# Altium schematic sheet Resonator filter design.SchDoc("Resonator filter design")
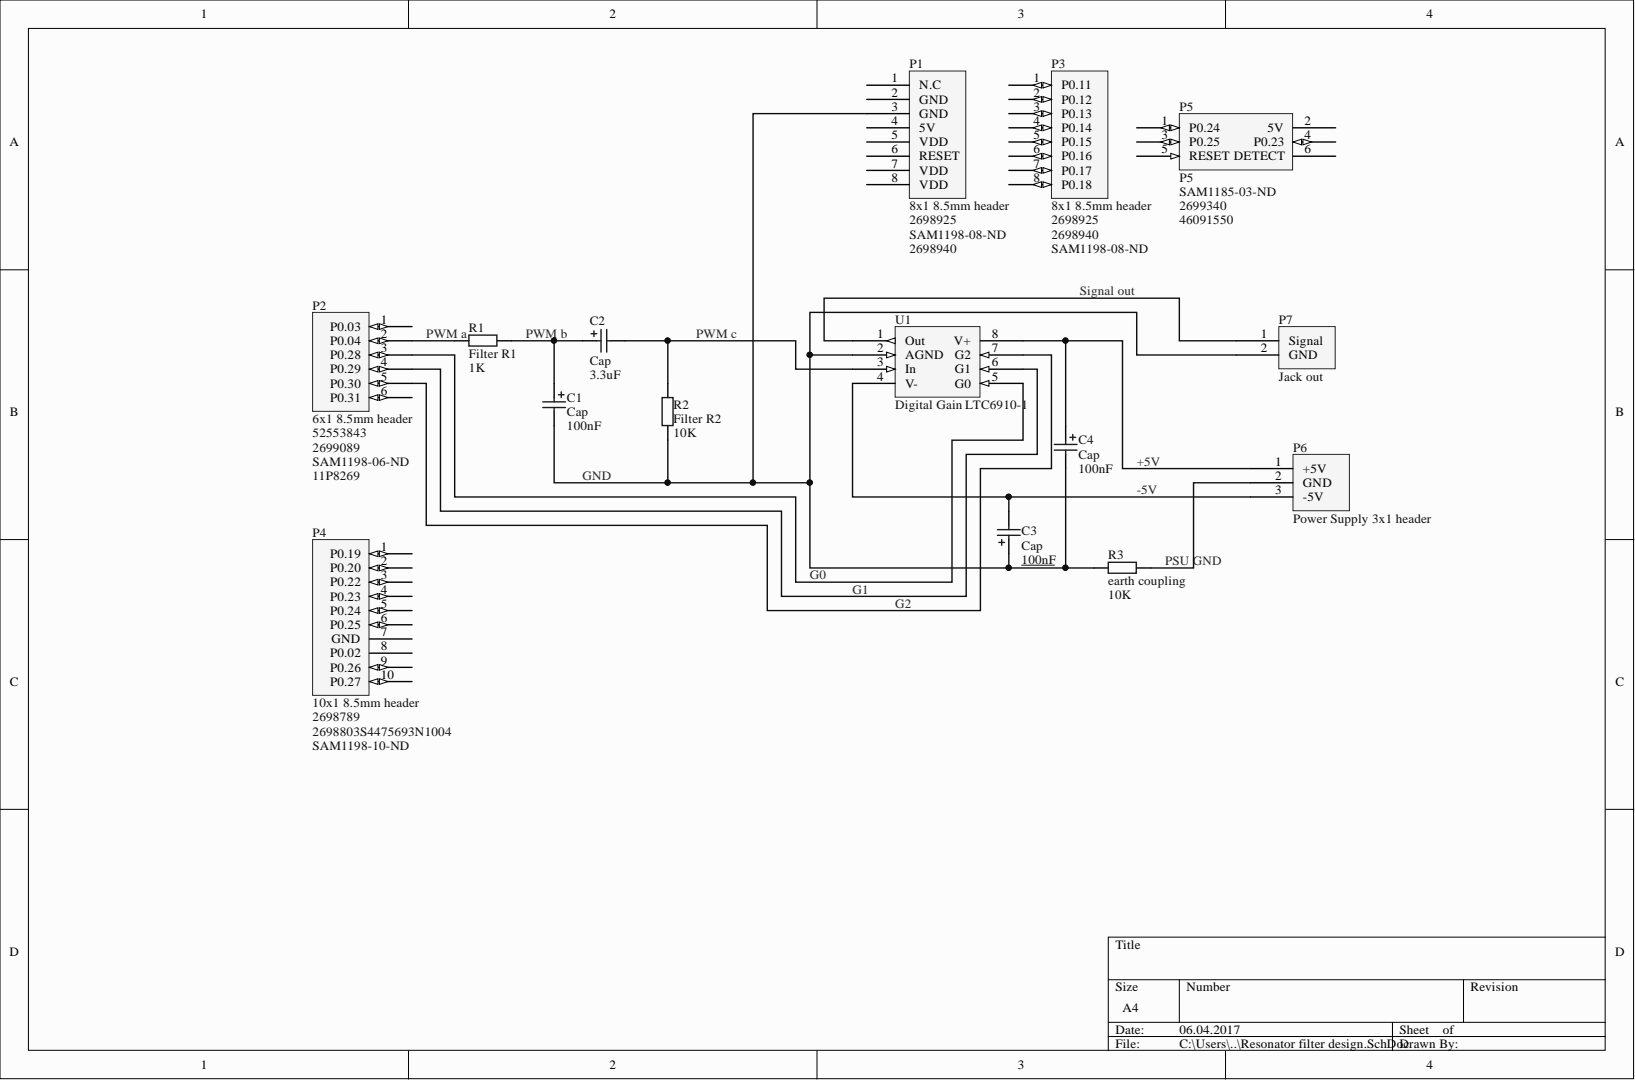
[diagram p0: Resonator filter design.SchDoc]

## Parts
C1  Cap  value 100nF  [Cap 100nF]
C2  Cap  value 3.3uF  [Cap 3.3uF]
C3  Cap  value 100nF  [Cap 100nF]
C4  Cap  value 100nF  [Cap 100nF]
P1  8x1 8.5mm header  Supplier Part Number 1: 2698925  [Header 8x1 8.5mm]
P2  6x1 8.5mm header  Supplier Part Number 1: 52553843  [Header 6x1 8.5mm]
P3  8x1 8.5mm header  Supplier Part Number 1: 2698925  [Header 8x1 8.5mm]
P4  10x1 8.5mm header  Supplier Part Number 1: 2698789  [Header 10x1 8.5mm]
P5  P5  Supplier Part Number 1: SAM1185-03-ND  [ICSP]
P6  Power Supply 3x1 header  [Power Supply connector]
P7  Jack out  [Jack out]
R1  Filter R1  value 1K  [AXIAL-0.4]
R2  Filter R2  value 10K  [AXIAL-0.4]
R3  earth coupling  value 10K  [AXIAL-0.4]
U1  Digital Gain LTC6910-1  [TSOT-23-8]

## Nets
+5V: C4.1 P6.1 U1.8(V+)
-5V: C3.2 P6.3 U1.4(V-)
G0: P2.3(P0.28) U1.5(G0)
G1: P2.4(P0.29) U1.6(G1)
G2: P2.5(P0.30) U1.7(G2)
GND: C1.2 C3.1 C4.2 P1.3(GND) P7.2 R2.1 R3.2 U1.2(AGND)
PSU GND: P6.2 R3.1
PWM a: P2.2(P0.04) R1.1
PWM b: C1.1 C2.1 R1.2
PWM c: C2.2 R2.2 U1.3(In)
Signal out: P7.1 U1.1(Out)

Not connected: P1 1(N.C) 2(GND) 4(5V) 5(VDD) 6(RESET) 7(VDD) 8(VDD); P2 1(P0.03) 6(P0.31); P3 1(P0.11) 2(P0.12) 3(P0.13) 4(P0.14) 5(P0.15) 6(P0.16) 7(P0.17) 8(P0.18); P4 1(P0.19) 2(P0.20) 3(P0.22) 4(P0.23) 5(P0.24) 6(P0.25) 7(GND) 8(P0.02) 9(P0.26) 10(P0.27); P5 1(P0.24) 2(5V) 3(P0.25) 4(P0.23) 5(RESET) 6(DETECT)

## Library data of the parts
C1-C4 = Cap Pol2: Polarized Capacitor (Axial); Simulation: CAP; Signal Integrity: Cap Pol; Published: 8-Jun-2000; PackageReference: POLAR0.8; PackageDescription: Polarized Capacitor; 2 Leads; Library Name: Miscellaneous Devices.IntLib; LatestRevisionNote: Re-released for DXP Platform.; LatestRevisionDate: 17-Jul-2002; Component Kind: Standard; Publisher: Altium Limited
P1 = POWER P1: Supplier Part Number 3: 2698940; Supplier Part Number 2: SAM1198-08-ND; Supplier 3: Arrow; Supplier 2: Digi-Key; Supplier 1: Arrow; Component Kind: Standard; Library Name: Resonator filter design.SCHLIB
P2 = P2: Supplier Part Number 4: 11P8269; Supplier Part Number 3: SAM1198-06-ND; Supplier Part Number 2: 2699089; Supplier 4: Newark; Supplier 3: Digi-Key; Supplier 2: Arrow; Supplier 1: Arrow; Component Kind: Standard; Library Name: Resonator filter design.SCHLIB
P3 = P3: Supplier Part Number 3: SAM1198-08-ND; Supplier Part Number 2: 2698940; Supplier 3: Digi-Key; Supplier 2: Arrow; Supplier 1: Arrow; Component Kind: Standard; Library Name: Resonator filter design.SCHLIB
P4 = P4: Supplier Part Number 3: SAM1198-10-ND; Supplier Part Number 2: 2698803S4475693N1004; Supplier 3: Digi-Key; Supplier 2: Arrow; Supplier 1: Arrow; Component Kind: Standard; Library Name: Resonator filter design.SCHLIB
P6 = Power Supply: Component Kind: Standard; Library Name: Resonator filter design.SCHLIB
R1-R3 = Res2: Resistor; Simulation: RESISTOR; Signal Integrity: Res; Published: 8-Jun-2000; PackageReference: AXIAL-0.4; PackageDescription: Axial Device, Thru-Hole; 2 Leads; 0.4 in Pin Spacing; Library Name: Miscellaneous Devices.IntLib; LatestRevisionNote: Re-released for DXP Platform.; LatestRevisionDate: 17-Jul-2002; Component Kind: Standard; Publisher: Altium Limited
P5 = P5: Supplier Part Number 3: 46091550; Supplier Part Number 2: 2699340; Supplier 3: Arrow; Supplier 2: Arrow; Supplier 1: Digi-Key; Component Kind: Standard; Library Name: Resonator filter design.SCHLIB
P7 = Jack out: Component Kind: Standard; Library Name: Resonator filter design.SCHLIB
U1 = Digital Gain LTC6910-1: Component Kind: Standard; Library Name: Resonator filter design.SCHLIB
